# Altium schematic sheet [02] PD_SRC.SchDoc("[02] PD_SRC")
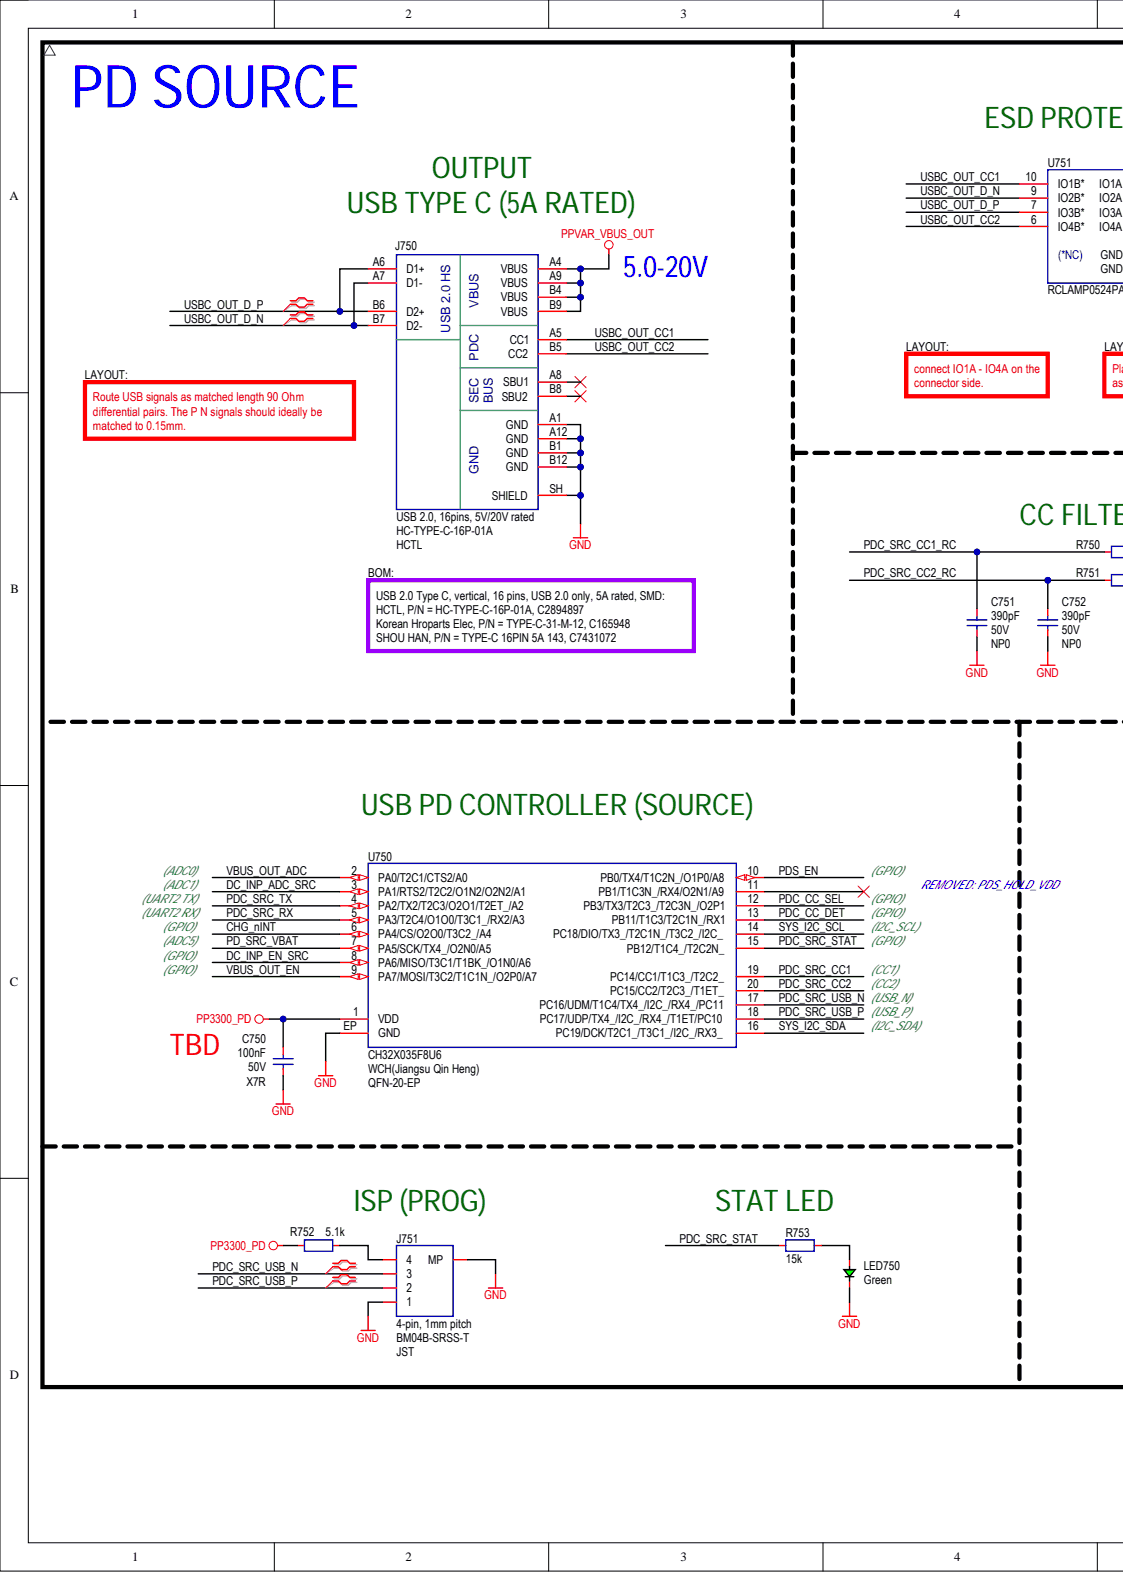
[diagram p0: [02] PD_SRC.SchDoc, left]
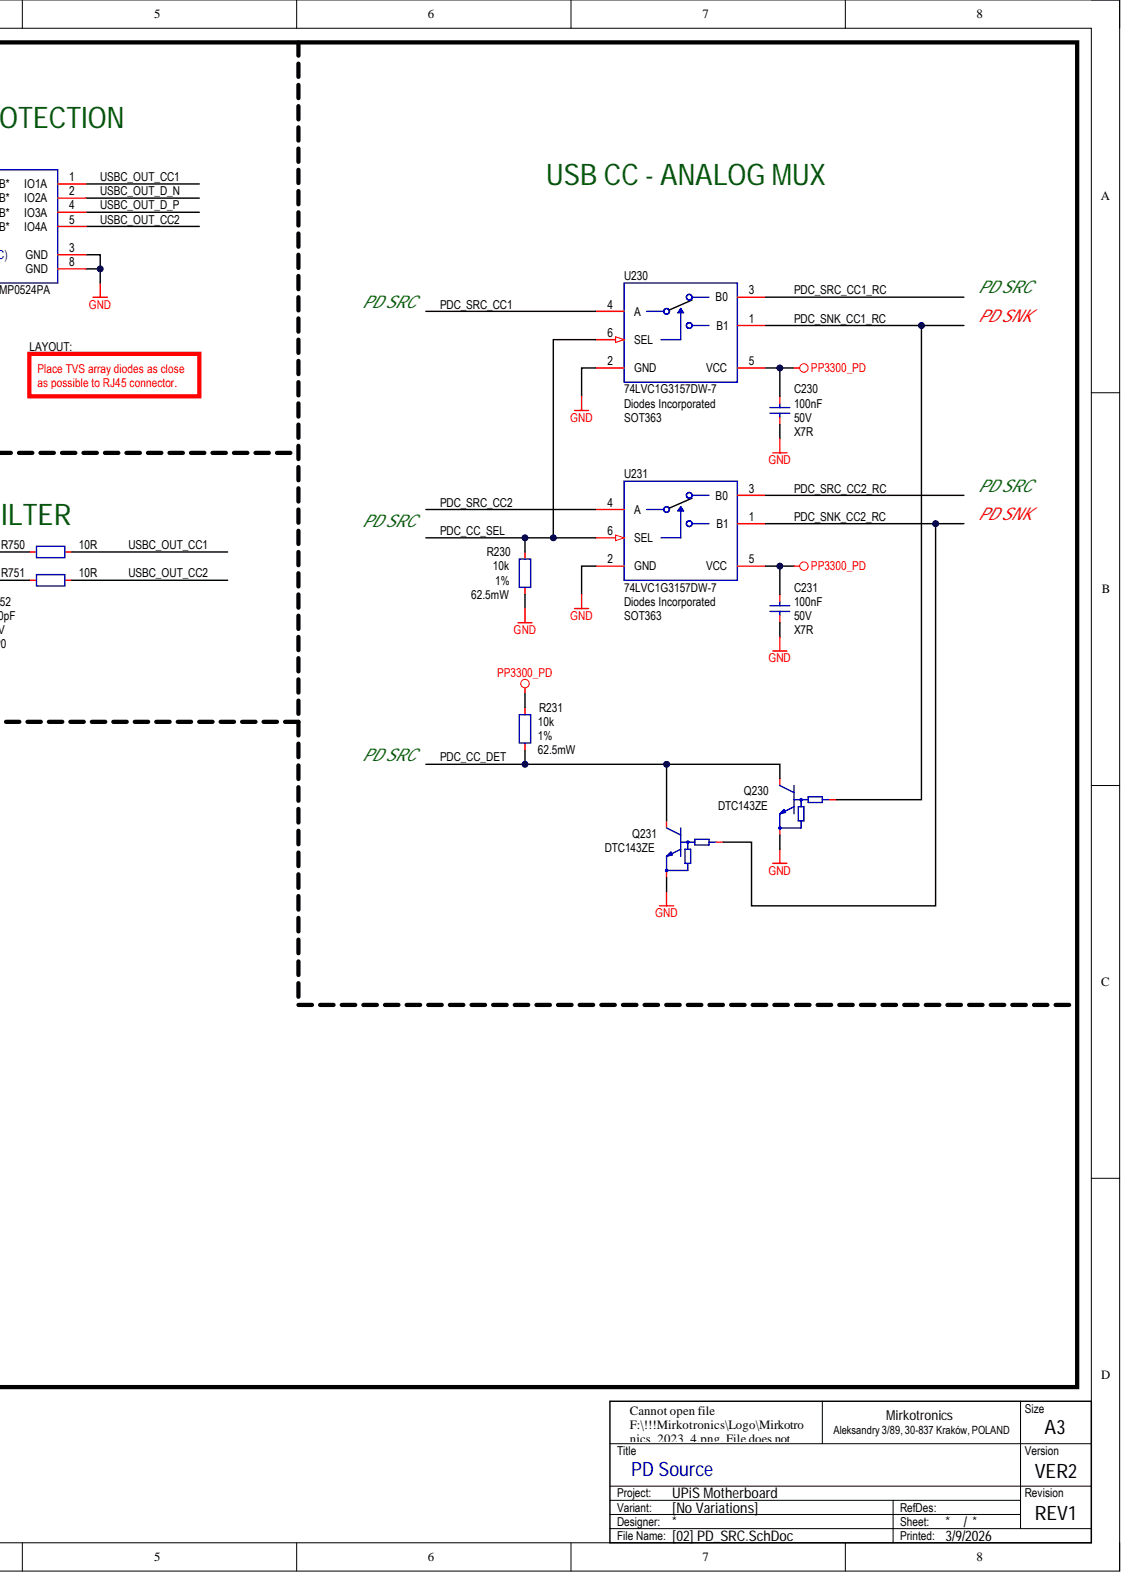
[diagram p1: [02] PD_SRC.SchDoc, right]

## Parts
C230  100nF  Dielectric: X7R  Voltage Rating: 50V
C231  100nF  Dielectric: X7R  Voltage Rating: 50V
C750  100nF  Dielectric: X7R  Voltage Rating: 50V
C751  390pF  Dielectric: NP0  Voltage Rating: 50V
C752  390pF  Dielectric: NP0  Voltage Rating: 50V
J750  USB 2.0, 16pins, 5V/20V rated  Manufacturer: HCTL  MPN: HC-TYPE-C-16P-01A
J751  4-pin, 1mm pitch  Manufacturer: JST  MPN: BM04B-SRSS-T
LED750  Green
Q230  DTC143ZE
Q231  DTC143ZE
R230  10k  Power Rating: 62.5mW  Tolerance: 1%
R231  10k  Power Rating: 62.5mW  Tolerance: 1%
R750  10R
R751  10R
R752  5.1k
R753  15k
U230  74LVC1G3157DW-7  Manufacturer: Diodes Incorporated  Package (Case): SOT363
U231  74LVC1G3157DW-7  Manufacturer: Diodes Incorporated  Package (Case): SOT363
U750  CH32X035  Manufacturer: WCH(Jiangsu Qin Heng)  MPN: CH32X035F8U6  Package (Case): QFN-20-EP
U751  RCLAMP0524PA

## Nets
GND: C230.2 C231.2 C750.2 C751.1 C752.1 J750.A1(GND) J750.A12(GND) J750.B1(GND) J750.B12(GND) J750.SH(SHIELD) J751.1 J751.MP LED750.1 Q230.2 Q231.2 R230.1 U230.2(GND) U231.2(GND) U750.EP(GND) U751.3(GND) U751.8(GND)
PP3300_PD: C230.1 C231.1 C750.1 R231.2 R752.2 U230.5(VCC) U231.5(VCC) U750.1(VDD)
PPVAR_VBUS_OUT: J750.A4(VBUS) J750.A9(VBUS) J750.B4(VBUS) J750.B9(VBUS)
CHG_nINT: U750.6(PA4/CS/O2O0/T3C2_/A4)
DC_INP_ADC_SRC: U750.3(PA1/RTS2/T2C2/O1N2/O2N2/A1)
DC_INP_EN_SRC: U750.8(PA6/MISO/T3C1/T1BK_/O1N0/A6)
PD_SRC_VBAT: U750.7(PA5/SCK/TX4_/O2N0/A5)
PDC_CC_DET: Q230.3 Q231.3 R231.1 U750.13(PB11/T1C3/T2C1N_/RX1)
PDC_CC_SEL: R230.2 U230.6(SEL) U231.6(SEL) U750.12(PB3/TX3/T2C3_/T2C3N_/O2P1)
PDC_SNK_CC1_RC: Q230.1 U230.1(B1)
PDC_SNK_CC2_RC: Q231.1 U231.1(B1)
PDC_SRC_CC1: U230.4(A) U750.19(PC14/CC1/T1C3_/T2C2_)
PDC_SRC_CC1_RC: C751.2 R750.1 U230.3(B0)
PDC_SRC_CC2: U231.4(A) U750.20(PC15/CC2/T2C3_/T1ET_)
PDC_SRC_CC2_RC: C752.2 R751.1 U231.3(B0)
PDC_SRC_RX: U750.5(PA3/T2C4/O1O0/T3C1_/RX2/A3)
PDC_SRC_STAT: R753.2 U750.15(PB12/T1C4_/T2C2N_)
PDC_SRC_TX: U750.4(PA2/TX2/T2C3/O2O1/T2ET_/A2)
PDC_SRC_USB_N: J751.3 U750.17(PC16/UDM/T1C4/TX4_/I2C_/RX4_/PC11)
PDC_SRC_USB_P: J751.2 U750.18(PC17/UDP/TX4_/I2C_/RX4_/T1ET/PC10)
PDS_EN: U750.10(PB0/TX4/T1C2N_/O1P0/A8)
SYS_I2C_SCL: U750.14(PC18/DIO/TX3_/T2C1N_/T3C2_/I2C_)
SYS_I2C_SDA: U750.16(PC19/DCK/T2C1_/T3C1_/I2C_/RX3_)
USBC_OUT_CC1: J750.A5(CC1) R750.2 U751.1(IO1A) U751.10(IO1B*)
USBC_OUT_CC2: J750.B5(CC2) R751.2 U751.5(IO4A) U751.6(IO4B*)
USBC_OUT_D_N: J750.A7(D1-) J750.B7(D2-) U751.2(IO2A) U751.9(IO2B*)
USBC_OUT_D_P: J750.A6(D1+) J750.B6(D2+) U751.4(IO3A) U751.7(IO3B*)
VBUS_OUT_ADC: U750.2(PA0/T2C1/CTS2/A0)
VBUS_OUT_EN: U750.9(PA7/MOSI/T3C2/T1C1N_/O2P0/A7)
NetJ751_4: J751.4 R752.1
NetLED750_2: LED750.2 R753.1

Not connected: J750 A8(SBU1) B8(SBU2); U750 11(PB1/T1C3N_/RX4/O2N1/A9)

## Library data of the parts
C230 C231 C750 = CAP-0004: Capacitor; MLCC; 100nF; 16VDC; X7R; 10%; Standard; SMD; 0402 (1005);; Manufacturer: Murata Electronics; MPN: GRM155R71H104KE14D; Component Body: 1.00 x 0.50mm; Database Table Name: CAPACITOR$; Packaging Type: Tape & Reel; Capacitance: 100nF; Component Height: 0.50mm; Component Kind: Standard; Component Subtype: MLCC; Component Type: Capacitor; Features: Standard; ID: CAP-0004; Mounting Type: SMD; Operating Temperature: -55°C to +125°C; Package (Case): 0402 (1005); ROHS Status: RoHS Compliant; Supplier 1: LCSC; Supplier 2: Mouser; Supplier 3: DigiKey; Supplier Part Number 1: C77020; Tolerance: 10%; Value: 100nF; Library Name: MirFol_db_local.DbLib
C751 C752 = CAP-0023: Capacitor; MLCC; GENERIC; TBD; TBD; TBD; TBD; SMD; 0603 (1608);; Manufacturer: YAGEO; MPN: CC0402JRNPO9BN391; Database Table Name: CAPACITOR$; Package (Case): 0402 (1005); Tolerance: 5%; Capacitance: 390pF; Component Kind: Standard; Component Subtype: MLCC; Component Type: Capacitor; Mounting Type: SMD; Operating Temperature: TBD; Supplier 1: LCSC; Supplier 2: Mouser; Supplier 3: DigiKey; Supplier Part Number 1: C282239; Value: 390pF; Library Name: MirFol_db_local.DbLib
J750 = CON_USB_TYPE_C_16P: Connector; USB 3.1 Type C; Receptacle; 24-pin; USB 2.0 Only;; Component Subtype: USB-C; Package (Case): SMD; Component Kind: Standard; Component Type: Connector; Mounting Type: SMD; Supplier 1: LCSC; Supplier 2: Mouser; Supplier 3: DigiKey; Supplier Part Number 1: C2894897; Value: USB 2.0, 16pins, 5V/20V rated; Library Name: MirFol_lib.SchLib
J751 = CON_1X04_COL_MP: Connector; 1 Row;; Component Subtype: Crimp; Package (Case): SMD; Specification: Connector, crimp style, 4-pin, 1mm pitch, vertical, receptacle, SMD; Component Kind: Standard; Component Type: Connector; Mounting Type: SMD; Supplier Part Number 1: C160390; Value: 4-pin, 1mm pitch; Library Name: MirFol_lib.SchLib
LED750 = DIO_LED_GRN: Diode; LED; Green;; Manufacturer: Foshan NationStar Optoelectronics; MPN: NCD0805G1; Component Subtype: LED Diode; Package (Case): 0805 (2012); Component Kind: Standard; Component Type: LED; Mounting Type: SMD; Supplier: LCSC; Supplier 1: LCSC; Supplier Part Number 1: C84260; Value: Green; Library Name: MirFol_lib.SchLib
Q230 Q231 = TRA_BJT_NPN_DIG_BEC: Transistor; BJT; NPN; Digital;; Manufacturer: Jiangsu Changjing Electronics Technology Co., Ltd.; Component Subtype: NPN Transistor; Package (Case): SOT-523; Component Kind: Standard; Component Type: Transistor; Mounting Type: SMD; MPN: DTC143ZE; Supplier 1: LCSC; Supplier 2: Mouser; Supplier 3: DigiKey; Supplier Part Number 1: C78593; Value: DTC143ZE; Library Name: MirFol_lib.SchLib
R230 R231 = RES-0004: Resistor; Thick Film; 10k; 1%; 62.5mW; 100PPM; 50V; Standard; SMD; 0402 (1005);; Manufacturer: Yageo Corporation; MPN: RC0402FR-0710KL; Component Body: 1.00 x 0.50mm; Database Table Name: RESISTOR$; Packaging Type: Tape & Reel; Component Height: 0.35mm; Component Kind: Standard; Component Subtype: Thick Film; Component Type: Resistor; Features: Standard; ID: RES-0004; Mounting Type: SMD; Operating Temperature: -55°C to +155°C; Package (Case): 0402 (1005); Resistance: 10k; ROHS Status: RoHS Compliant; Supplier 1: LCSC; Supplier 2: Farnell; Supplier 3: Mouser; Supplier Part Number 1: C60490; Supplier Part Number 2: 9239359; Supplier Part Number 3: 603-RC0402FR-0710KL; TCR: 100PPM; Value: 10k; Voltage Rating: 50V; Library Name: MirFol_db_local.DbLib
R750 R751 = RES-0003: Resistor; Thick Film; 1k; 1%; 62.5mW; 100PPM; 50V; Standard; SMD; 0402 (1005);; Manufacturer: Yageo Corporation; MPN: RC0402FR-0710RL; Component Body: 1.00 x 0.50mm; Database Table Name: RESISTOR$; Packaging Type: Tape & Reel; Component Height: 0.35mm; Component Kind: Standard; Component Subtype: Thick Film; Component Type: Resistor; Features: Standard; ID: RES-0003; Mounting Type: SMD; Operating Temperature: -55°C to +155°C; Package (Case): 0402 (1005); Power Rating: 62.5mW; Resistance: 10R; ROHS Status: RoHS Compliant; Supplier 1: LCSC; Supplier 2: Mouser; Supplier 3: Digikey; Supplier Part Number 1: C138066; TCR: 100PPM; Tolerance: 1%; Value: 10R; Voltage Rating: 50V; Library Name: MirFol_db_local.DbLib
R752 = RES-0058: Resistor; Thick Film; GENERIC; TBD; TBD; TBD; TBD; TBD; SMD; 0402 (1005);; Manufacturer: YAGEO; MPN: RC0402FR-075K1L; Component Body: 1.00 x 0.50mm; Database Table Name: RESISTOR$; Packaging Type: Tape & Reel; Power Rating: 62.5mW; TCR: 100PPM; Tolerance: 1%; Voltage Rating: 50V; Component Height: 0.35mm; Component Kind: Standard; Component Subtype: Thick Film; Component Type: Resistor; Features: Standard; ID: RES-0058; Mounting Type: SMD; Operating Temperature: -55°C to +155°C; Package (Case): 0402 (1005); Resistance: 5.1k; ROHS Status: RoHS Compliant; Supplier 1: LCSC; Supplier 2: Mouser; Supplier 3: Digikey; Supplier Part Number 1: C105872; Supplier Part Number 2: 603-RC0402FR-075K1L; Supplier Part Number 3: 311-5.10KLRTR-ND; Value: 5.1k; Library Name: MirFol_db_local.DbLib
R753 = RES-0058: Resistor; Thick Film; GENERIC; TBD; TBD; TBD; TBD; TBD; SMD; 0402 (1005);; Manufacturer: YAGEO; MPN: RC0402FR-0715KL; Component Body: 1.00 x 0.50mm; Database Table Name: RESISTOR$; Packaging Type: Tape & Reel; Power Rating: 62.5mW; TCR: 100PPM; Tolerance: 1%; Voltage Rating: 50V; Component Height: 0.35mm; Component Kind: Standard; Component Subtype: Thick Film; Component Type: Resistor; Features: Standard; ID: RES-0058; Mounting Type: SMD; Operating Temperature: -55°C to +155°C; Package (Case): 0402 (1005); Resistance: 15k; ROHS Status: RoHS Compliant; Supplier 1: LCSC; Supplier 2: Mouser; Supplier 3: Digikey; Supplier Part Number 1: C114761; Supplier Part Number 2: 603-RC0402FR-0715KL; Supplier Part Number 3: 311-15.0KLRTR-ND; Value: 15k; Library Name: MirFol_db_local.DbLib
U230 U231 = IC_NXP_74LVC1G3157GW: SPDT analog switch; Component Kind: Standard; Component Type: IC; Library Name: EDS500-lib.SchLib; Mounting Type: SMD; MPN: 74LVC1G3157DW-7; Operating Temperature: -40°C to +125°C; Specification: SPDT analog switch; Supplier 1: LCSC; Supplier 2: Mouser; Supplier 3: DigiKey; Value: 74LVC1G3157DW-7
U750 = ICC_WCH_CH32X035F8U6_QFN20: IC; RISC-V MCU;; Component Kind: Standard; Component Type: IC; Mounting Type: SMD; Operating Temperature: -XX°C to +XX°C; Supplier 1: LCSC; Supplier 2: Mouser; Supplier 3: DigiKey; Supplier Part Number 1: C42442062; Value: CH32X035; Library Name: Pineberry_Lib.SchLib
U751 = ICC-0005: IC; TVS Arrays; ESD Protection; 4-Channel; 17kV Air; 12kV Contact; 0.3pF Capitance; TBD; SMD; TBD;; Manufacturer: Semtech Corporation; MPN: RCLAMP0524PATCT; Database Table Name: IC (MISC)$; Component Kind: Standard; Component Subtype: TVS Arrays; Component Type: IC; Mounting Type: SMD; Package (Case): SMD; Supplier 1: LCSC; Supplier Part Number 1: C40960; Value: RCLAMP0524PA; Library Name: MirFol_db_local.DbLib
